# Altium schematic sheet BMS Carrier - Battery Relay Controls.SchDoc("BMS Carrier - Battery Relay Controls")
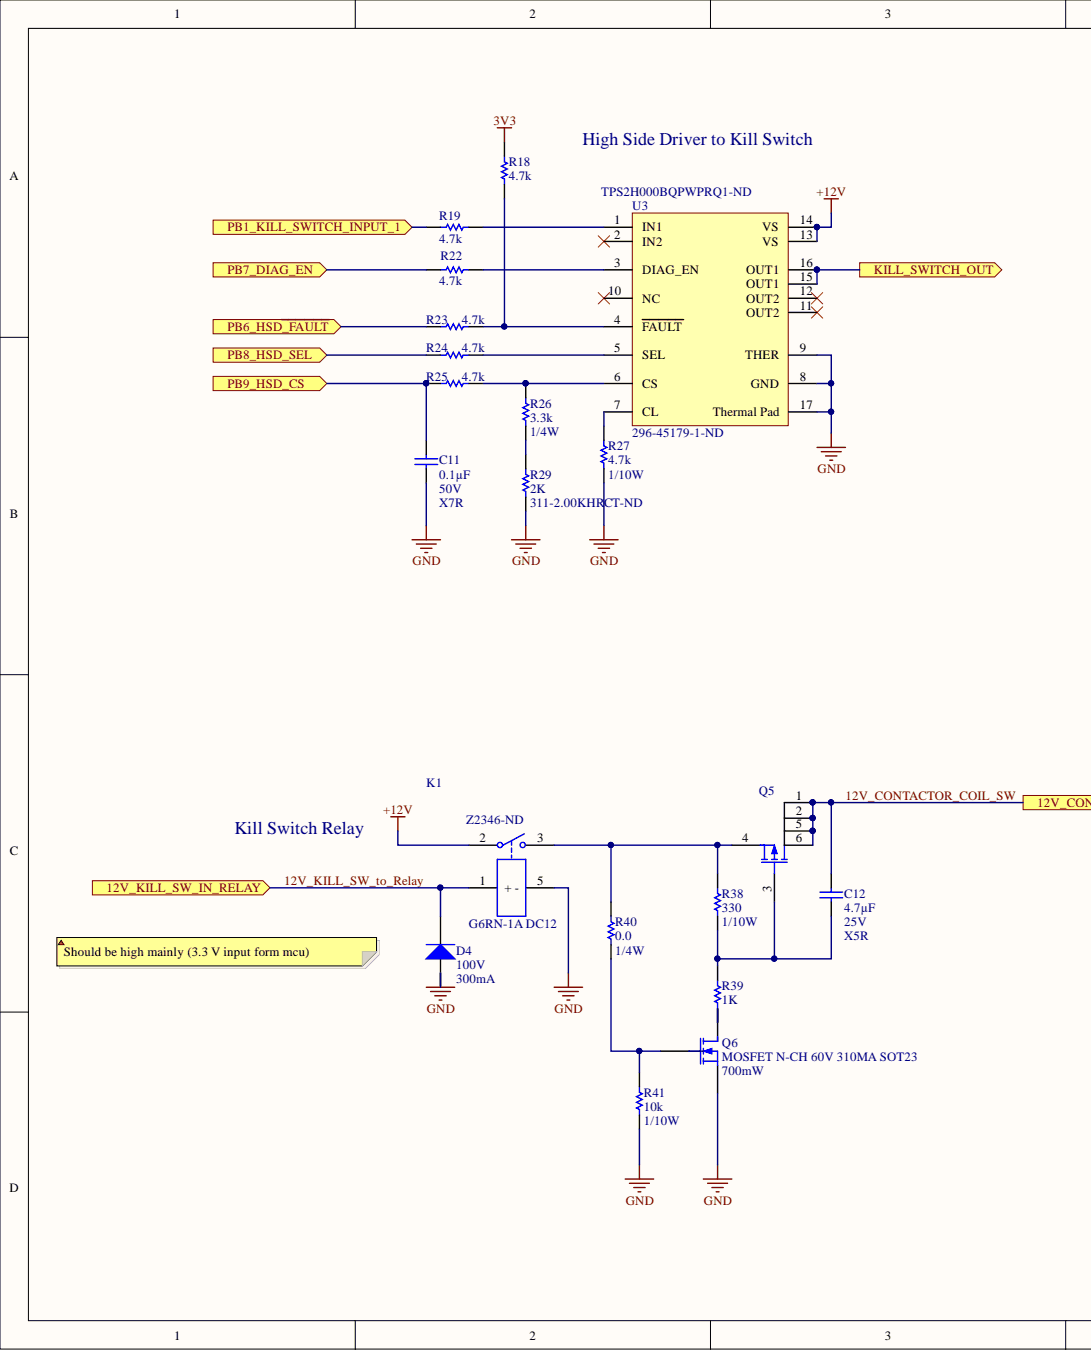
[diagram p0: BMS Carrier - Battery Relay Controls.SchDoc, left]
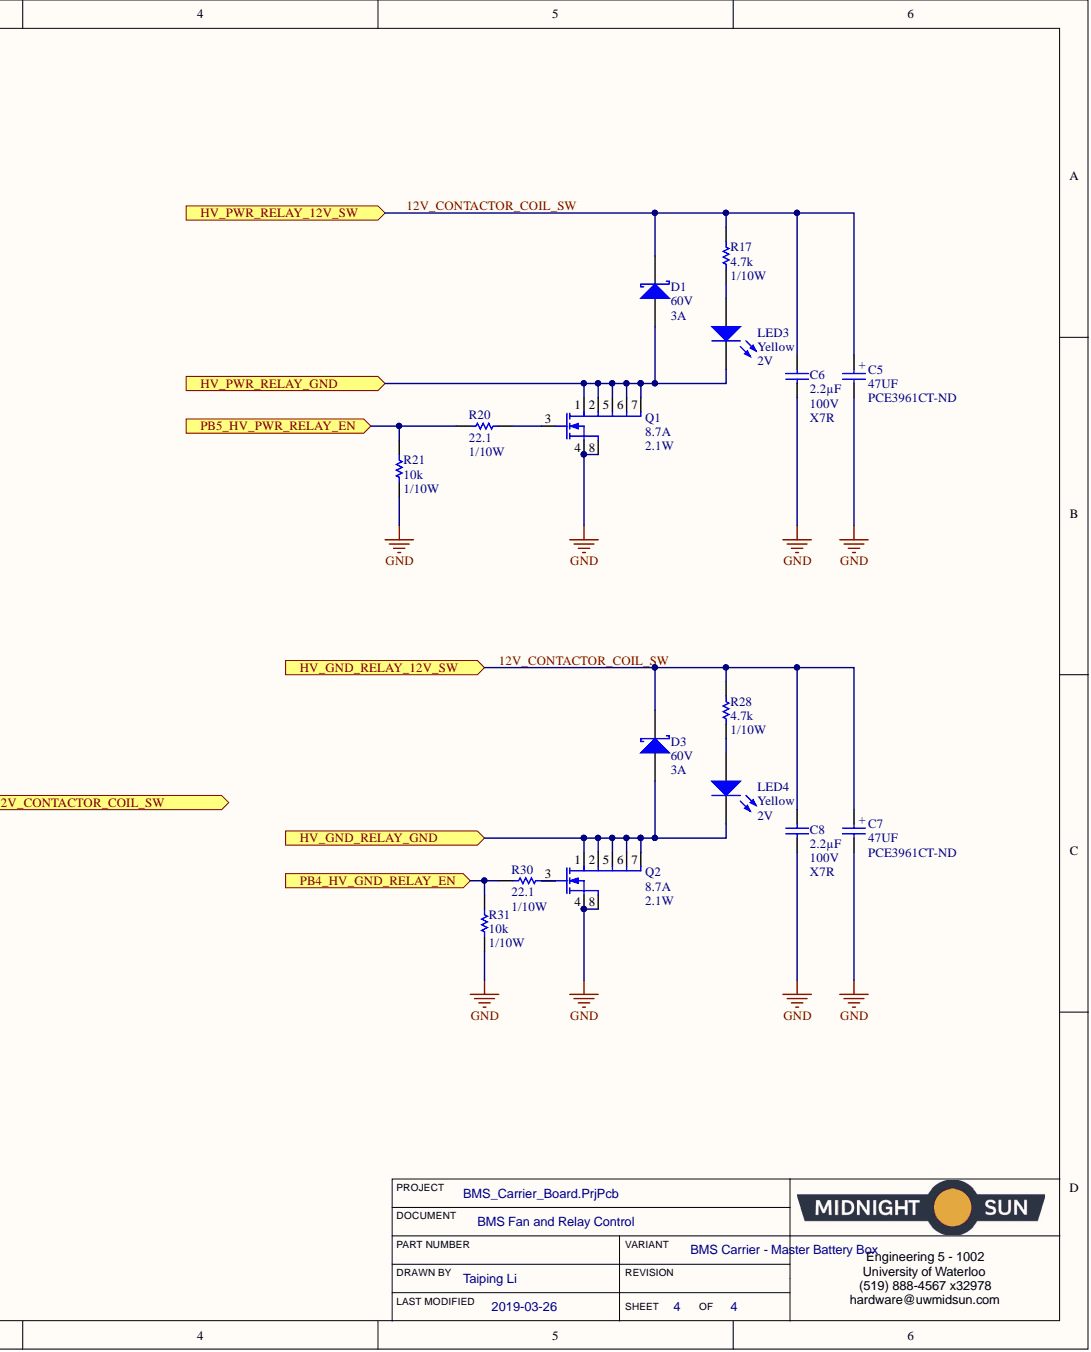
[diagram p1: BMS Carrier - Battery Relay Controls.SchDoc, right]

## Parts
C5  47UF  Supplier Part Number 1: PCE3961CT-ND  [CAP ALUM 6.3MM RADIAL SMD]
C6  Voltage: 100V  Temperature Coefficient: X7R  Capacitance: 2.2µF  [CAP, 1206]  (hidden comment: CAP CER 2.2UF 100V ±20% X7R 1206)
C7  47UF  Supplier Part Number 1: PCE3961CT-ND  [CAP ALUM 6.3MM RADIAL SMD]
C8  Voltage: 100V  Temperature Coefficient: X7R  Capacitance: 2.2µF  [CAP, 1206]  (hidden comment: CAP CER 2.2UF 100V ±20% X7R 1206)
C11  Voltage: 50V  Temperature Coefficient: X7R  Capacitance: 0.1µF  [CAP, 0603]  (hidden comment: CAP CER 0.1UF 50V 10% X7R 0603)
C12  Voltage: 25V  Temperature Coefficient: X5R  Capacitance: 4.7µF  [CAP, 0603]  (hidden comment: CAP CER 4.7UF 25V 10% X5R 0603)
D1  Voltage - DC Reverse (Vr) (Max): 60V  Current - Average Rectified (Io): 3A  [Diode, SMA]  (hidden comment: DIODE SCHOTTKY 60V 3A SMA)
D3  Voltage - DC Reverse (Vr) (Max): 60V  Current - Average Rectified (Io): 3A  [Diode, SMA]  (hidden comment: DIODE SCHOTTKY 60V 3A SMA)
D4  Max Repetitive Reverse Voltage (Vrrm): 100V  Forward Current: 300mA  [DIODE GEN PURP 100V 300MA SOD123]  (hidden comment: DIODE GEN PURP 100V 300MA SOD123)
K1  G6RN-1A DC12  Supplier Part Number 2: Z2346-ND  [RELAY SPST 8A 12VDC]
LED3  Voltage - Forward (Vf) (Typ): 2V  Color: Yellow  [LED_0603]  (hidden comment: LED YELLOW CLEAR 2.1V 0603)
LED4  Voltage - Forward (Vf) (Typ): 2V  Color: Yellow  [LED_0603]  (hidden comment: LED YELLOW CLEAR 2.1V 0603)
Q1  Power Dissipation (Max): 2.1W  Current - Continuous Drain (Id) @ 25°C: 8.7A  [MOSFET N-CH 30V 12A 2mmx2mm PQFN]  (hidden comment: MOSFET N-CH 30V 8.7A 2.1W 6-PQFN (2x2))
Q2  Power Dissipation (Max): 2.1W  Current - Continuous Drain (Id) @ 25°C: 8.7A  [MOSFET N-CH 30V 12A 2mmx2mm PQFN]  (hidden comment: MOSFET N-CH 30V 8.7A 2.1W 6-PQFN (2x2))
Q5  MOSFET P-CH 30V 4A 1.6W SOT-23-6  [P-MOSFET]  (value not drawn)
Q6  MOSFET N-CH 60V 310MA SOT23  Max Power Dissipation: 700mW  [SOT23]
R17  Resistance (Ohms): 4.7k  Power (Watts): 1/10W  [RES, 0603]  (hidden comment: RES 4.7K OHM 1% 1/10W 0603)
R18  Resistance (Ohms): 4.7k  [RES, 0603]  (hidden comment: RES 10K OHM 1% 1/10W 0603)
R19  Resistance (Ohms): 4.7k  [RES, 0603]  (hidden comment: RES 4.7K OHM 1% 1/10W 0603)
R20  Resistance: 22.1  Power: 1/10W  [RES, 0603]  (hidden comment: RES 22.1 OHM 1% 1/10W 0603)
R21  Resistance: 10k  Power: 1/10W  [RES, 0603]  (hidden comment: RES 10K OHM 1% 1/10W 0603)
R22  Resistance (Ohms): 4.7k  [RES, 0603]  (hidden comment: RES 4.7K OHM 1% 1/10W 0603)
R23  Resistance (Ohms): 4.7k  [RES, 0603]  (hidden comment: RES 4.7K OHM 1% 1/10W 0603)
R24  Resistance (Ohms): 4.7k  [RES, 0603]  (hidden comment: RES 4.7K OHM 1% 1/10W 0603)
R25  Resistance (Ohms): 4.7k  [RES, 0603]  (hidden comment: RES 4.7K OHM 1% 1/10W 0603)
R26  Resistance: 3.3k  Power: 1/4W  [RES, 0603]  (hidden comment: RES 3.3K OHM 1% 1/4W 0603)
R27  Resistance (Ohms): 4.7k  Power (Watts): 1/10W  [RES, 0603]  (hidden comment: RES 4.7K OHM 1% 1/10W 0603)
R28  Resistance (Ohms): 4.7k  Power (Watts): 1/10W  [RES, 0603]  (hidden comment: RES 4.7K OHM 1% 1/10W 0603)
R29  2K  Supplier Part Number 1: 311-2.00KHRCT-ND  [RES, 0603]
R30  Resistance: 22.1  [RES, 0603]  (hidden comment: RES 22.1 OHM 1% 1/10W 0603)
R31  Resistance: 10k  Power: 1/10W  [RES, 0603]  (hidden comment: RES 10K OHM 1% 1/10W 0603)
R38  Power: 1/10W  [RES, 0603]  (hidden comment: RES 330 OHM 1% 1/10W 0603)
R39  1K  [RES, 0603]
R40  Resistance: 0.0  Power (Watts): 1/4W  [RES, 0603]  (hidden comment: RES 0.0 OHM 1/4W 0603)
R41  Resistance: 10k  Power: 1/10W  [RES, 0603]  (hidden comment: RES 10K OHM 1% 1/10W 0603)
U3  296-45179-1-ND  Supplier Part Number 1: TPS2H000BQPWPRQ1-ND  [IC HSD Dual-Channel TSOP65P640X120_HS-17N]

## Ports
12V_CONTACTOR_COIL_SW, 12V_KILL_SW_IN_RELAY, HV_GND_RELAY_12V_SW, HV_GND_RELAY_GND, HV_PWR_RELAY_12V_SW, HV_PWR_RELAY_GND, KILL_SWITCH_OUT, PB1_KILL_SWITCH_INPUT_1, PB4_HV_GND_RELAY_EN, PB5_HV_PWR_RELAY_EN, PB6_HSD~{_FAULT}, PB7_DIAG_EN, PB8_HSD_SEL, PB9_HSD_CS

## Nets
3V3: R18.2
+12V: K1.2 U3.13(VS) U3.14(VS)
GND: C5.2 C6.2 C7.2 C8.2 C11.2 D4.1 K1.5(-) Q1.4 Q1.8 Q2.4 Q2.8 Q6.2 R21.2 R27.2 R29.2 R31.2 R41.2 U3.8(GND) U3.9(THER) U3.17(Thermal_Pad)
12V_CONTACTOR_COIL_SW: C5.1 C6.1 C7.1 C8.1 C12.1 D1.2 D3.2 Q5.1 Q5.2 Q5.5 Q5.6 R17.1 R28.1 ; port 12V_CONTACTOR_COIL_SW, port HV_GND_RELAY_12V_SW, port HV_PWR_RELAY_12V_SW
12V_KILL_SW_to_Relay: D4.2 K1.1(+) ; port 12V_KILL_SW_IN_RELAY
HV_GND_RELAY_GND: D3.1 LED4.2 Q2.1 Q2.2 Q2.5 Q2.6 Q2.7 ; port HV_GND_RELAY_GND
HV_PWR_RELAY_GND: D1.1 LED3.2 Q1.1 Q1.2 Q1.5 Q1.6 Q1.7 ; port HV_PWR_RELAY_GND
KILL_SWITCH_OUT: U3.15(OUT1) U3.16(OUT1) ; port KILL_SWITCH_OUT
PB1_KILL_SWITCH_INPUT_1: R19.1 ; port PB1_KILL_SWITCH_INPUT_1
PB4_HV_GND_RELAY_EN: R30.1 R31.1 ; port PB4_HV_GND_RELAY_EN
PB5_HV_PWR_RELAY_EN: R20.1 R21.1 ; port PB5_HV_PWR_RELAY_EN
PB6_HSD~{_FAULT}: R23.1 ; port PB6_HSD~{_FAULT}
PB7_DIAG_EN: R22.1 ; port PB7_DIAG_EN
PB8_HSD_SEL: R24.1 ; port PB8_HSD_SEL
PB9_HSD_CS: C11.1 R25.1 ; port PB9_HSD_CS
NetC12_2: C12.2 Q5.3 R38.2 R39.1
NetK1_3: K1.3 Q5.4 R38.1 R40.1
NetLED3_1: LED3.1 R17.2
NetLED4_1: LED4.1 R28.2
NetQ1_3: Q1.3 R20.2
NetQ2_3: Q2.3 R30.2
NetQ6_1: Q6.1 R39.2
NetQ6_3: Q6.3 R40.2 R41.1
NetR18_1: R18.1 R23.2 U3.4(FAULT)
NetR19_2: R19.2 U3.1(IN1)
NetR22_2: R22.2 U3.3(DIAG_EN)
NetR24_2: R24.2 U3.5(SEL)
NetR25_2: R25.2 R26.1 U3.6(CS)
NetR26_2: R26.2 R29.1
NetR27_1: R27.1 U3.7(CL)
N00054:

Not connected: U3 2(IN2) 10(NC) 11(OUT2) 12(OUT2)

## Library data of the parts
C5 C7 = CAP ALUM 47UF 20% 35V SMD: 47µF 35V Aluminum Electrolytic Capacitors Radial, Can - SMD 1000 Hrs @ 85°C; Manufacturer Part Number: EEE-1VA470WP; Manufacturer: Panasonic; Tolerance: 20%; Ripple Current (AC): 70mA; Life (Hours): 1000Hour; Voltage Rating (DC): 35V; Voltage Rating: 35V; Termination: SMD/SMT; Supplier 1: Digi-Key; Stock 1: 2820; Ripple Current: 70mA; REACH SVHC: Unknown; Radiation Hardening: No; Pricing 1: 1=0.38, 10=0.263, 100=0.1579, 500=0.11846 (USD); Packaging: Tape and Reel; Package Quantity: 1; Mount: Surface Mount,PCB; Min Operating Temperature: -40°C; Max Operating Temperature: 85°C; Length: 5.5mm; Lead Free: Lead Free; Height - Seated (Max): 0.213inch; Height: 5.4mm; Diameter: 6.3mm; Contact Plating: Tin; ComponentLink6URL: https://datasheet.ciiva.com/30206/11aba0000ce2-30206253.pdf; ComponentLink6Description: https://datasheet.ciiva.com/30206/11aba0000ce2-30206253.pdf; ComponentLink5URL: https://datasheet.ciiva.com/16507/aba0000ce2-16507965.pdf; ComponentLink5Description: https://datasheet.ciiva.com/16507/aba0000ce2-16507965.pdf; ComponentLink4URL: https://datasheet.ciiva.com/15165/getdatasheetpartid-86239-15165868.pdf; ComponentLink4Description: https://datasheet.ciiva.com/15165/getdatasheetpartid-86239-15165868.pdf; ComponentLink3URL: https://datasheet.ciiva.com/14598/70255710-14598937.pdf; ComponentLink3Description: https://datasheet.ciiva.com/14598/70255710-14598937.pdf; ComponentLink2URL: https://datasheet.ciiva.com/2983/aba0000ce2-2983927.pdf; ComponentLink2Description: https://datasheet.ciiva.com/2983/aba0000ce2-2983927.pdf; ComponentLink1URL: https://datasheet.ciiva.com/2983/aba0000ce2-2983926.pdf; ComponentLink1Description: https://datasheet.ciiva.com/2983/aba0000ce2-2983926.pdf; Component Kind: Standard; Case/Package: Radial; Capacitance: 47uF; Library Name: Schematic Diagrams.SchLib
C6 C8 = CAP CER 2.2UF 100V ±20% X7R 1206: 2.2µF ±20% 100V Ceramic Capacitor X7R 1206 (3216 Metric); Manufacturer Part Number 1: GRM31CR72A225MA73L; Manufacturer 1: Murata Electronics North America; Tolerance: ±20%; Thickness (Max): 0.071" (1.80mm); Supplier Part Number 1: 490-12773-1-ND; Supplier 1: Digi-Key; Size / Dimension: 0.126" L x 0.063" W (3.20mm x 1.60mm); Ratings: -; Part Status: Active; Packaging: Cut Tape (CT); Package / Case: 1206 (3216 Metric); Operating Temperature: -55°C ~ 125°C; Mounting Type: Surface Mount, MLCC; Lead Style: -; Lead Spacing: -; Height - Seated (Max): -; ComponentLink1URL: http://www.murata.com/~/media/webrenewal/support/library/catalog/products/capacitor/mlcc/c02e.ashx; ComponentLink1Description: http://www.murata.com/~/media/webrenewal/support/library/catalog/products/capacitor/mlcc/c02e.ashx; Component Kind: Standard; Library Name: Schematic Diagrams.SchLib
C11 = CAP CER 0.1UF 50V 10% X7R 0603: 0.10µF ±10% 50V Ceramic Capacitor X7R 0603 (1608 Metric); Manufacturer Part Number 1: 06035C104KAT2A; Manufacturer 1: AVX Corporation; Tolerance: ±10%; Thickness (Max): 0.035" (0.90mm); Supplier Part Number 1: 478-5052-1-ND; Supplier 1: Digi-Key; Size / Dimension: 0.063" L x 0.032" W (1.60mm x 0.81mm); Ratings: -; Part Status: Active; Packaging: Cut Tape (CT); Package / Case: 0603 (1608 Metric); Operating Temperature: -55°C ~ 125°C; Mounting Type: Surface Mount, MLCC; Lead Style: -; Lead Spacing: -; Height - Seated (Max): -; ComponentLink1URL: http://datasheets.avx.com/X7RDielectric.pdf; ComponentLink1Description: http://datasheets.avx.com/X7RDielectric.pdf; Component Kind: Standard; Library Name: Schematic Diagrams.SchLib
C12 = CAP CER 4.7UF 25V 10% X5R 0603: 4.7µF ±10% 25V Ceramic Capacitor X5R 0603 (1608 Metric); Manufacturer Part Number 1: GRM188R61E475KE11D; Manufacturer 1: Murata Electronics North America; Tolerance: ±10%; Thickness (Max): 0.031" (0.80mm); Supplier Part Number 1: 490-7203-1-ND; Supplier 1: Digi-Key; Size / Dimension: 0.063" L x 0.031" W (1.60mm x 0.80mm); Ratings: -; Part Status: Active; Packaging: Cut Tape (CT); Package / Case: 0603 (1608 Metric); Operating Temperature: -55°C ~ 85°C; Mounting Type: Surface Mount, MLCC; Lead Style: -; Lead Spacing: -; Height - Seated (Max): -; ComponentLink1URL: http://www.murata.com/~/media/webrenewal/support/library/catalog/products/capacitor/mlcc/c02e.ashx; ComponentLink1Description: http://www.murata.com/~/media/webrenewal/support/library/catalog/products/capacitor/mlcc/c02e.ashx; Component Kind: Standard; Library Name: Schematic Diagrams.SchLib
D1 D3 = DIODE SCHOTTKY 60V 3A SMA: Diode Schottky 60V 3A Surface Mount SMA; Manufacturer Part Number 1: B360A-13-F; Manufacturer 1: Diodes Incorporated; Voltage - Forward (Vf) (Max) @ If: 700mV @ 3A; Speed: Fast Recovery =< 500ns, > 200mA (Io); Current - Reverse Leakage @ Vr: 500µA @ 60V; Capacitance @ Vr, F: 200pF @ 4V, 1MHz; Supplier Part Number 1: B360A-FDICT-ND; Supplier Device Package: SMA; Supplier 1: Digi-Key; Part Status: Active; Packaging: Cut Tape (CT); Package / Case: DO-214AC, SMA; Operating Temperature - Junction: -55°C ~ 150°C; Mounting Type: Surface Mount; Diode Type: Schottky; ComponentLink1URL: https://www.diodes.com/assets/Datasheets/ds30891.pdf; ComponentLink1Description: https://www.diodes.com/assets/Datasheets/ds30891.pdf; Component Kind: Standard; Library Name: Schematic Diagrams.SchLib
D4 = DIODE GEN PURP 100V 300MA SOD123: Diode Standard 100V 300mA (DC) Surface Mount SOD-123; Manufacturer Part Number: 1N4148WQ-7-F; Manufacturer: Diodes Zetex; Reverse Recovery Time: 4ns; Peak Reverse Current: 50uA; Peak Non-Repetitive Surge Current: 2A; Max Power Dissipation: 400mW; Forward Voltage: 1.25V; Element Configuration: Single; Weight: 0.000353oz; Supplier Part Number 1: 1N4148WQ-7-FDICT-ND; Supplier 1: Digi-Key; Stock 1: 229; Recovery Time: 4ns; Pricing 1: 1=0.22, 10=0.196, 100=0.107, 500=0.0658, 1000=0.0449 (USD); Packaging: Tape and Reel; Package Quantity: 3000; Number of Pins: 2; Mount: Surface Mount; Min Operating Temperature: -65°C; Max Surge Current: 2A; Max Operating Temperature: 150°C; Lead Free: Lead Free; Contact Plating: Tin,Matte; ComponentLink3URL: http://www.mouser.com/ds/2/115/ds30086-318161.pdf; ComponentLink3Description: http://www.mouser.com/ds/2/115/ds30086-318161.pdf; ComponentLink2URL: http://www.diodes.com/datasheets/ds30086.pdf; ComponentLink2Description: http://www.diodes.com/datasheets/ds30086.pdf; ComponentLink1URL: http://components.arrow.com/part/dataview/0323_07206809; ComponentLink1Description: http://components.arrow.com/part/dataview/0323_07206809; Component Kind: Standard; Case/Package: SOD-123-2; Library Name: Schematic Diagrams.SchLib
K1 = RELAY SPST 12V 8A OMRON: Omron Relay SPST (1 Form A) Through Hole; Switching Voltage: 250VAC, 30VDC - Max; Operate Time: 15ms; Coil Voltage: 12V; Coil Type: Non Latching; Coil Power: 220 mW; Supplier 2: Digi-Key; Supplier 1: Digi-Key; Manufacturer Part Number: G6RN-1A DC12; Contact Form: SPST; ComponentLink1URL: https://omronfs.omron.com/en_US/ecb/products/pdf/en-g6rn.pdf; ComponentLink1Description: Datasheet; Component Kind: Standard; Library Name: Schematic Diagrams.SchLib
LED3 LED4 = LED YELLOW CLEAR 2.1V 0603: Yellow 590nm LED Indication - Discrete 2V 0603 (1608 Metric); Manufacturer Part Number: 150060YS75000; Manufacturer: Wurth Electronics Inc.; Wavelength - Peak: 595nm; Viewing Angle: 140°; Millicandela Rating: 120mcd; Lens Style/Size: Rectangle with Flat Top, 1.10mm x 0.70mm; Lens Color: Colorless; Current - Test: 20mA; Wavelength - Dominant: 590nm; Supplier Part Number 1: 732-4981-1-ND; Supplier Device Package: 0603; Supplier 1: Digi-Key; Stock 1: 10523; Size / Dimension: 1.60mm L x 0.80mm W; RoHS: RoHS Compliant; Pricing 1: 1=0.21, 100=0.1617, 500=0.13964 (CAD); Part Status: Active; Packaging: Cut Tape (CT); Package / Case: 0603 (1608 Metric); Mounting Type: Surface Mount; Lens Transparency: Clear; Height (Max): 0.80mm; Features: -; ComponentLink1URL: http://katalog.we-online.de/led/datasheet/150060YS75000.pdf; ComponentLink1Description: http://katalog.we-online.de/led/datasheet/150060YS75000.pdf; Component Kind: Standard; Category: Optoelectronics; Library Name: Schematic Diagrams.SchLib
Q1 Q2 = MOSFET N-CH 30V 8.7A 2.1W 6-PQFN (2x2): Manufacturer Part Number 1: IRLHS6342TRPBF; Manufacturer 1: Infineon Technologies; Vgs(th) (Max) @ Id: 1.1V @ 10µA; Vgs (Max): ±12V; Rds On (Max) @ Id, Vgs: 15.5 mOhm @ 8.5A, 4.5V; Input Capacitance (Ciss) (Max) @ Vds: 1019pF @ 25V; Gate Charge (Qg) (Max) @ Vgs: 11nC @ 4.5V; FET Type: N-Channel; Drive Voltage (Max Rds On,  Min Rds On): 2.5V, 4.5V; Description 1: MOSFET N-CH 30V 8.7A 2X2 PQFN; Technology: MOSFET (Metal Oxide); Supplier Part Number 1: IRLHS6342TRPBFCT-ND; Supplier Device Package: 6-PQFN (2x2); Supplier 1: Digi-Key; Stock 1: 9984; RoHS: RoHS Compliant; Pricing 1: 1=0.79, 10=0.685, 100=0.5118, 500=0.4022, 1000=0.31079 (CAD); Part Status: Active; Packaging: Cut Tape (CT); Package / Case: 6-PowerVDFN; Operating Temperature: -55°C ~ 150°C (TJ); Mounting Type: Surface Mount; FET Feature: -; Drain to Source Voltage (Vdss): 30V; ComponentLink1URL: http://www.infineon.com/dgdl/irlhs6342pbf.pdf?fileId=5546d462533600a401535663b52625ad; ComponentLink1Description: http://www.infineon.com/dgdl/irlhs6342pbf.pdf?fileId=5546d462533600a401535663b52625ad; Component Kind: Standard; Category: Discrete Semiconductor Products; Library Name: Schematic Diagrams.SchLib
Q5 = MOSFET P-CH 30V 4A 1.6W SOT-23-6: P-Channel 30V 4A (Ta) 1.6W (Ta) Surface Mount SOT-23-6; Manufacturer Part Number 1: STT4P3LLH6; Manufacturer 1: STMicroelectronics; Vgs(th) (Max) @ Id: 2.5V @ 250µA; Vgs (Max): ±20V; Rds On (Max) @ Id, Vgs: 56 mOhm @ 2A, 10V; Input Capacitance (Ciss) (Max) @ Vds: 639pF @ 25V; Gate Charge (Qg) (Max) @ Vgs: 6nC @ 4.5V; Drive Voltage (Max Rds On,  Min Rds On): 4.5V, 10V; Current - Continuous Drain (Id) @ 25°C: 4A (Ta); Technology: MOSFET (Metal Oxide); Supplier Part Number 1: 497-15521-1-ND; Supplier Device Package: SOT-23-6; Supplier 1: Digi-Key; Simulation: PMOS; Power Dissipation (Max): 1.6W (Ta); Part Status: Active; Packaging: Cut Tape (CT); Package / Case: SOT-23-6; Operating Temperature: 150°C (TJ); Mounting Type: Surface Mount; FET Type: P-Channel; FET Feature: -; Drain to Source Voltage (Vdss): 30V; ComponentLink1URL: http://www.st.com/content/ccc/resource/technical/document/datasheet/13/e4/a1/65/8b/79/44/73/DM00084060.pdf/files/DM00084060.pdf/jcr:content/translations/en.DM00084060.pdf; ComponentLink1Description: http://www.st.com/content/ccc/resource/technical/document/datasheet/13/e4/a1/65/8b/79/44/73/DM00084060.pdf/files/DM00084060.pdf/jcr:content/translations/en.DM00084060.pdf; Component Kind: Standard; Library Name: Schematic Diagrams.SchLib
Q6 = MOSFET N-CH 60V 310MA SOT23: N-Channel 60V 310mA (Ta) 370mW (Ta) Surface Mount SOT-23; Manufacturer Part Number: DMN6140L-7; Manufacturer: Diodes; Turn-On Delay Time: 2.6ns; Turn-Off Delay Time: 16.3ns; Threshold Voltage: 3V; Rise Time: 3.6ns; Rds On Max: 140mR; Power Dissipation: 540mW; Number of Elements: 1; Input Capacitance: 315pF; Gate to Source Voltage (Vgs): 20V; FET Type: 1 N-Channel; Fall Time: 2.7ns; Element Configuration: Single; Continuous Drain Current (ID): 1.6A; Width: 1.4mm; Weight: 0.000282oz; Supplier Part Number 1: DMN65D8L-7DICT-ND; Supplier 1: Digi-Key; Stock 1: 0; REACH SVHC: No SVHC; Packaging: Tape and Reel; Package Quantity: 3000; Number of Pins: 3; Number of Channels: 1; Mount: Surface Mount; Min Operating Temperature: -55°C; Max Operating Temperature: 150°C; Length: 3mm; Lead Free: Lead Free; Height: 1.1mm; Drain to Source Voltage (Vdss): 60V; Drain to Source Resistance: 140mR; Drain to Source Breakdown Voltage: 60V; ComponentLink6URL: https://datasheet.ciiva.com/6371/dmn65d8l-6371128.pdf; ComponentLink6Description: https://datasheet.ciiva.com/6371/dmn65d8l-6371128.pdf; ComponentLink5URL: https://datasheet.ciiva.com/13058/dmn65d8l-219098-13058455.pdf; ComponentLink5Description: https://datasheet.ciiva.com/13058/dmn65d8l-219098-13058455.pdf; ComponentLink4URL: https://datasheet.ciiva.com/6371/dmn65d8l-6371126.pdf; ComponentLink4Description: https://datasheet.ciiva.com/6371/dmn65d8l-6371126.pdf; ComponentLink3URL: https://datasheet.ciiva.com/6371/dmn65d8l-6371124.pdf; ComponentLink3Description: https://datasheet.ciiva.com/6371/dmn65d8l-6371124.pdf; ComponentLink2URL: https://datasheet.ciiva.com/29747/dmn6140l-29747660.pdf; ComponentLink2Description: https://datasheet.ciiva.com/29747/dmn6140l-29747660.pdf; ComponentLink1URL: https://datasheet.ciiva.com/29747/dmn6140l-29747654.pdf; ComponentLink1Description: https://datasheet.ciiva.com/29747/dmn6140l-29747654.pdf; Component Kind: Standard; Case/Package: TO-236-3; Library Name: Schematic Diagrams.SchLib
R17-R19 R22-R25 R27 R28 = RES 4.7K OHM 1% 1/10W 0603: 4.7k Ohm ±1% 0.1W, 1/10W Chip Resistor 0603 (1608 Metric) Moisture Resistant Thick Film; Manufacturer Part Number 1: RC0603FR-074K7L; Manufacturer 1: Yageo; Temperature Coefficient: ±100ppm/°C; Description 1: RES SMD 4.7K OHM 1% 1/10W 0603; Tolerance: ±1%; Supplier Part Number 1: 311-4.70KHRCT-ND; Supplier Device Package: 0603; Supplier 1: Digi-Key; Stock 1: 3487566; Size / Dimension: 0.063" L x 0.031" W (1.60mm x 0.80mm); RoHS: RoHS Compliant; Pricing 1: 1=0.16, 10=0.022, 100=0.0089, 1000=0.00402, 2500=0.00349 (CAD); Part Status: Active; Packaging: Cut Tape (CT); Package / Case: 0603 (1608 Metric); Operating Temperature: -55°C ~ 155°C; Height - Seated (Max): 0.022" (0.55mm); Features: Moisture Resistant; Failure Rate: -; Composition: Thick Film; ComponentLink1URL: http://www.yageo.com/documents/recent/PYu-RC_Group_51_RoHS_L_7.pdf; ComponentLink1Description: http://www.yageo.com/documents/recent/PYu-RC_Group_51_RoHS_L_7.pdf; Component Kind: Standard; Category: Resistors; Library Name: Schematic Diagrams.SchLib
  R18 R19 R22-R25: Power (Watts): 1/10W
R20 R30 = RES 22.1 OHM 1% 1/10W 0603: 22.1 Ohm ±1% 0.1W, 1/10W Chip Resistor 0603 (1608 Metric) Moisture Resistant Thick Film; Manufacturer Part Number 1: RC0603FR-0722R1L; Manufacturer 1: Yageo; Temperature Coefficient: ±100ppm/°C; Tolerance: ±1%; Supplier Part Number 1: 311-22.1HRCT-ND; Supplier Device Package: 0603; Supplier 1: Digi-Key; Size / Dimension: 0.063" L x 0.031" W (1.60mm x 0.80mm); Part Status: Active; Packaging: Cut Tape (CT); Package / Case: 0603 (1608 Metric); Operating Temperature: -55°C ~ 155°C; Height - Seated (Max): 0.022" (0.55mm); Failure Rate: -; Composition: Thick Film; ComponentLink1URL: http://www.yageo.com/documents/recent/PYu-RC_Group_51_RoHS_L_7.pdf; ComponentLink1Description: http://www.yageo.com/documents/recent/PYu-RC_Group_51_RoHS_L_7.pdf; Component Kind: Standard; Library Name: Schematic Diagrams.SchLib
  R30: Power: 1/10W
R21 R31 R41 = RES 10K OHM 1% 1/10W 0603: RES SMD 10K OHM 1% 1/10W 0603; Manufacturer Part Number 1: RC0603FR-0710KL; Manufacturer 1: Yageo; Temperature Coefficient: ±100ppm/°C; Tolerance: ±1%; Supplier Part Number 1: 311-10.0KHRCT-ND; Supplier Device Package: 0603; Supplier 1: Digi-Key; Size / Dimension: 0.063" L x 0.031" W (1.60mm x 0.80mm); Part Status: Active; Packaging: Cut Tape (CT); Package / Case: 0603 (1608 Metric); Operating Temperature: -55°C ~ 155°C; Height - Seated (Max): 0.022" (0.55mm); Failure Rate: -; Composition: Thick Film; ComponentLink1URL: http://www.yageo.com/documents/recent/PYu-RC_Group_51_RoHS_L_7.pdf; ComponentLink1Description: http://www.yageo.com/documents/recent/PYu-RC_Group_51_RoHS_L_7.pdf; Component Kind: Standard; Library Name: Schematic Diagrams.SchLib
R26 = RES 3.3K OHM 1% 1/4W 0603: 3.3k Ohm ±1% 0.25W, 1/4W Chip Resistor 0603 (1608 Metric) Automotive AEC-Q200, Pulse Withstanding Thick Film; Manufacturer Part Number 1: ERJ-PA3F3301V; Manufacturer 1: Panasonic Electronic Components; Temperature Coefficient: ±100ppm/°C; Tolerance: ±1%; Supplier Part Number 1: P3.3KBYCT-ND; Supplier Device Package: 0603; Supplier 1: Digi-Key; Size / Dimension: 0.063" L x 0.031" W (1.60mm x 0.80mm); Part Status: Active; Packaging: Cut Tape (CT); Package / Case: 0603 (1608 Metric); Operating Temperature: -55°C ~ 155°C; Height - Seated (Max): 0.022" (0.55mm); Failure Rate: -; Composition: Thick Film; ComponentLink1URL: https://industrial.panasonic.com/cdbs/www-data/pdf/RDO0000/AOA0000C291.pdf; ComponentLink1Description: https://industrial.panasonic.com/cdbs/www-data/pdf/RDO0000/AOA0000C291.pdf; Component Kind: Standard; Library Name: Schematic Diagrams.SchLib
R29 = RES 2K OHM 1% 1/10W 0603: 2k Ohm ±1% 0.1W, 1/10W Chip Resistor 0603 (1608 Metric) Moisture Resistant Thick Film; Manufacturer Part Number: RC0603FR-072KL; Manufacturer: Yageo; Temperature Coefficient: ±100ppm/°C; Tolerance: ±1%; Supplier Device Package: 0603; Supplier 1: Digi-Key; Stock 1: 1750701; Size / Dimension: 0.063" L x 0.031" W (1.60mm x 0.80mm); RoHS: RoHS Compliant; Resistance (Ohms): 2k; Pricing 1: 1=0.15, 10=0.023, 100=0.0093, 1000=0.00415, 2500=0.0036 (CAD); Power (Watts): 0.1W, 1/10W; Part Status: Active; Packaging: Cut Tape (CT); Package / Case: 0603 (1608 Metric); Operating Temperature: -55°C ~ 155°C; Height - Seated (Max): 0.022" (0.55mm); Features: Moisture Resistant; Failure Rate: -; Composition: Thick Film; ComponentLink1URL: http://www.yageo.com/documents/recent/PYu-RC_Group_51_RoHS_L_7.pdf; ComponentLink1Description: http://www.yageo.com/documents/recent/PYu-RC_Group_51_RoHS_L_7.pdf; Component Kind: Standard; Category: Resistors; Library Name: Schematic Diagrams.SchLib
R38 = RES 330 OHM 1% 1/10W 0603: 330 Ohms ±1% 0.1W, 1/10W Chip Resistor 0603 (1608 Metric) Automotive AEC-Q200, Moisture Resistant Thick Film; Manufacturer Part Number: 1-2176339-9; Manufacturer: TE Connectivity; Resistance: 330; Supplier Part Number 1: A129682TR-ND; Supplier 1: Digi-Key; Stock 1: 0; Component Kind: Standard; Library Name: Schematic Diagrams.SchLib
R39 = RES 1K OHM 5% 1/10W 0603: 1k Ohm ±5% 0.1W, 1/10W Chip Resistor 0603 (1608 Metric); Manufacturer Part Number: RC0603JR-071KL; Manufacturer: Yageo; Temperature Coefficient: ±100ppm/°C; Tolerance: ±5%; Supplier Part Number 1: 311-1.0KGRCT-ND; Supplier Device Package: 0603; Supplier 1: Digi-Key; Stock 1: 4415240; Size / Dimension: 0.063" L x 0.031" W (1.60mm x 0.80mm); RoHS: RoHS Compliant; Resistance (Ohms): 1k; Pricing 1: 1=0.15, 10=0.017, 100=0.0071, 1000=0.0032, 2500=0.00278 (CAD); Power (Watts): 0.1W, 1/10W; Part Status: Active; Packaging: Cut Tape (CT); Package / Case: 0603 (1608 Metric); Operating Temperature: -55°C ~ 155°C; Height - Seated (Max): 0.022" (0.55mm); Features: Moisture Resistant; Failure Rate: -; Composition: Thick Film; ComponentLink1URL: http://www.yageo.com/documents/recent/PYu-RC_Group_51_RoHS_L_7.pdf; ComponentLink1Description: http://www.yageo.com/documents/recent/PYu-RC_Group_51_RoHS_L_7.pdf; Component Kind: Standard; Category: Resistors; Library Name: Schematic Diagrams.SchLib
R40 = RES 0.0 OHM 1/4W 0603: RES SMD 0.0 OHM JUMPER 1/4W 0603; Manufacturer Part Number 1: CRCW06030000Z0EAHP; Manufacturer 1: Vishay Dale; Supplier Part Number 1: 541-0.0SBCT-ND; Supplier Device Package: 0603; Supplier 1: Digi-Key; Size / Dimension: 0.063" L x 0.033" W (1.60mm x 0.85mm); Part Status: Active; Packaging: Cut Tape (CT); Package / Case: 0603 (1608 Metric); Operating Temperature: -55°C ~ 155°C; Height - Seated (Max): 0.022" (0.55mm); Failure Rate: -; Composition: Thick Film; ComponentLink1URL: http://www.vishay.com/docs/20043/crcwhpe3.pdf; ComponentLink1Description: http://www.vishay.com/docs/20043/crcwhpe3.pdf; Component Kind: Standard; Library Name: Schematic Diagrams.SchLib
U3 = IC HSD Dual-Channel 40V 1KOhm: Supplier Part Number: 296-45179-1-ND; Supplier 1: Digi-Key; Manufacturer Part Number: TPS2H000BQPWPRQ1-ND; Description: IC HSD Dual-Channel 40V 1KOhm; ComponentLink1URL: http://www.ti.com/lit/ds/symlink/tps2h000-q1.pdf; ComponentLink1Description: Datasheet; Component Kind: Standard; Library Name: Schematic Diagrams.SchLib
